# Altium schematic sheet Connectors.SchDoc(Connectors)
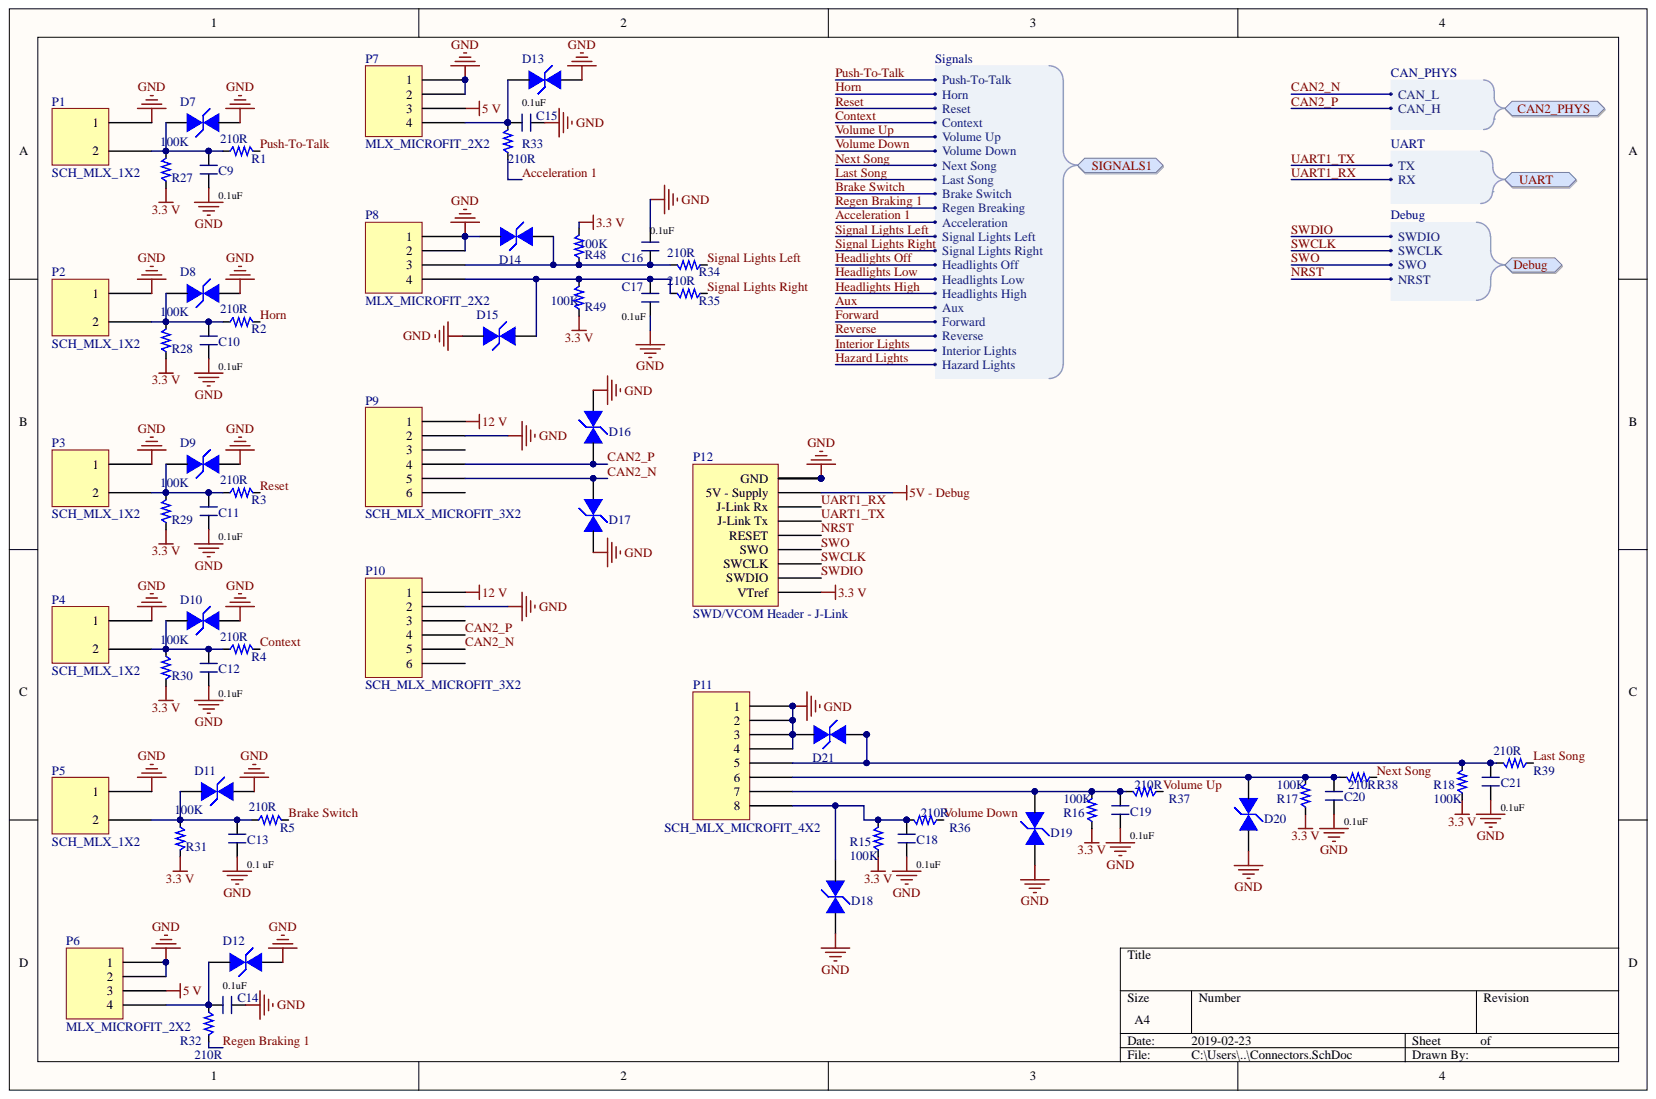
[diagram p0: Connectors.SchDoc]

## Parts
C9  Capacitance: 0.1uF  [PCB_GENERIC_SMD_0603]  (hidden comment: SCH_GENERIC_CAPACITOR_0603)
C10  Capacitance: 0.1uF  [PCB_GENERIC_SMD_0603]  (hidden comment: SCH_GENERIC_CAPACITOR_0603)
C11  Capacitance: 0.1uF  [PCB_GENERIC_SMD_0603]  (hidden comment: SCH_GENERIC_CAPACITOR_0603)
C12  Capacitance: 0.1uF  [PCB_GENERIC_SMD_0603]  (hidden comment: SCH_GENERIC_CAPACITOR_0603)
C13  Capacitance: 0.1 uF  [PCB_GENERIC_SMD_0603]  (hidden comment: SCH_GENERIC_CAPACITOR_0603)
C14  Capacitance: 0.1uF  [PCB_GENERIC_SMD_0603]  (hidden comment: SCH_GENERIC_CAPACITOR_0603)
C15  Capacitance: 0.1uF  [PCB_GENERIC_SMD_0603]  (hidden comment: SCH_GENERIC_CAPACITOR_0603)
C16  Capacitance: 0.1uF  [PCB_GENERIC_SMD_0603]  (hidden comment: SCH_GENERIC_CAPACITOR_0603)
C17  Capacitance: 0.1uF  [PCB_GENERIC_SMD_0603]  (hidden comment: SCH_GENERIC_CAPACITOR_0603)
C18  Capacitance: 0.1uF  [PCB_GENERIC_SMD_0603]  (hidden comment: SCH_GENERIC_CAPACITOR_0603)
C19  Capacitance: 0.1uF  [PCB_GENERIC_SMD_0603]  (hidden comment: SCH_GENERIC_CAPACITOR_0603)
C20  Capacitance: 0.1uF  [PCB_GENERIC_SMD_0603]  (hidden comment: SCH_GENERIC_CAPACITOR_0603)
C21  Capacitance: 0.1uF  [PCB_GENERIC_SMD_0603]  (hidden comment: SCH_GENERIC_CAPACITOR_0603)
D7  TVS Diode  [TVS Diode]  (value not drawn)
D8  TVS Diode  [TVS Diode]  (value not drawn)
D9  TVS Diode  [TVS Diode]  (value not drawn)
D10  TVS Diode  [TVS Diode]  (value not drawn)
D11  TVS Diode  [TVS Diode]  (value not drawn)
D12  TVS Diode  [TVS Diode]  (value not drawn)
D13  TVS Diode  [TVS Diode]  (value not drawn)
D14  TVS Diode  [TVS Diode]  (value not drawn)
D15  TVS Diode  [TVS Diode]  (value not drawn)
D16  TVS Diode  [TVS Diode]  (value not drawn)
D17  TVS Diode  [TVS Diode]  (value not drawn)
D18  TVS Diode  [TVS Diode]  (value not drawn)
D19  TVS Diode  [TVS Diode]  (value not drawn)
D20  TVS Diode  [TVS Diode]  (value not drawn)
D21  TVS Diode  [TVS Diode]  (value not drawn)
P1  SCH_MLX_1X2  [PCB_MLX_MICROFIT_1X2]
P2  SCH_MLX_1X2  [PCB_MLX_MICROFIT_1X2]
P3  SCH_MLX_1X2  [PCB_MLX_MICROFIT_1X2]
P4  SCH_MLX_1X2  [PCB_MLX_MICROFIT_1X2]
P5  SCH_MLX_1X2  [PCB_MLX_MICROFIT_1X2]
P6  MLX_MICROFIT_2X2  [MLX_MICROFIT_2X2]
P7  MLX_MICROFIT_2X2  [MLX_MICROFIT_2X2]
P8  MLX_MICROFIT_2X2  [MLX_MICROFIT_2X2]
P9  SCH_MLX_MICROFIT_3X2  [PCB_MLX_MICROFIT_3X2]
P10  SCH_MLX_MICROFIT_3X2  [PCB_MLX_MICROFIT_3X2]
P11  SCH_MLX_MICROFIT_4X2  [PCB_MLX_MICROFIT_4X2]
P12  SWD/VCOM Header - J-Link  [Samtec TST-110-01-G-D]
R1  Resistance: 210R  [PCB_GENERIC_SMD_0603]  (hidden comment: SCH_GENERIC_RESISTOR_0603)
R2  Resistance: 210R  [PCB_GENERIC_SMD_0603]  (hidden comment: SCH_GENERIC_RESISTOR_0603)
R3  Resistance: 210R  [PCB_GENERIC_SMD_0603]  (hidden comment: SCH_GENERIC_RESISTOR_0603)
R4  Resistance: 210R  [PCB_GENERIC_SMD_0603]  (hidden comment: SCH_GENERIC_RESISTOR_0603)
R5  Resistance: 210R  [PCB_GENERIC_SMD_0603]  (hidden comment: SCH_GENERIC_RESISTOR_0603)
R15  Resistance: 100K  [PCB_GENERIC_SMD_0603]  (hidden comment: SCH_GENERIC_RESISTOR_0603)
R16  Resistance: 100K  [PCB_GENERIC_SMD_0603]  (hidden comment: SCH_GENERIC_RESISTOR_0603)
R17  Resistance: 100K  [PCB_GENERIC_SMD_0603]  (hidden comment: SCH_GENERIC_RESISTOR_0603)
R18  Resistance: 100K  [PCB_GENERIC_SMD_0603]  (hidden comment: SCH_GENERIC_RESISTOR_0603)
R27  Resistance: 100K  [PCB_GENERIC_SMD_0603]  (hidden comment: SCH_GENERIC_RESISTOR_0603)
R28  Resistance: 100K  [PCB_GENERIC_SMD_0603]  (hidden comment: SCH_GENERIC_RESISTOR_0603)
R29  Resistance: 100K  [PCB_GENERIC_SMD_0603]  (hidden comment: SCH_GENERIC_RESISTOR_0603)
R30  Resistance: 100K  [PCB_GENERIC_SMD_0603]  (hidden comment: SCH_GENERIC_RESISTOR_0603)
R31  Resistance: 100K  [PCB_GENERIC_SMD_0603]  (hidden comment: SCH_GENERIC_RESISTOR_0603)
R32  Resistance: 210R  [PCB_GENERIC_SMD_0603]  (hidden comment: SCH_GENERIC_RESISTOR_0603)
R33  Resistance: 210R  [PCB_GENERIC_SMD_0603]  (hidden comment: SCH_GENERIC_RESISTOR_0603)
R34  Resistance: 210R  [PCB_GENERIC_SMD_0603]  (hidden comment: SCH_GENERIC_RESISTOR_0603)
R35  Resistance: 210R  [PCB_GENERIC_SMD_0603]  (hidden comment: SCH_GENERIC_RESISTOR_0603)
R36  Resistance: 210R  [PCB_GENERIC_SMD_0603]  (hidden comment: SCH_GENERIC_RESISTOR_0603)
R37  Resistance: 210R  [PCB_GENERIC_SMD_0603]  (hidden comment: SCH_GENERIC_RESISTOR_0603)
R38  SCH_GENERIC_RESISTOR_0603  [PCB_GENERIC_SMD_0603]  (value not drawn)
R39  Resistance: 210R  [PCB_GENERIC_SMD_0603]  (hidden comment: SCH_GENERIC_RESISTOR_0603)
R48  Resistance: 100K  [PCB_GENERIC_SMD_0603]  (hidden comment: SCH_GENERIC_RESISTOR_0603)
R49  Resistance: 100K  [PCB_GENERIC_SMD_0603]  (hidden comment: SCH_GENERIC_RESISTOR_0603)

## Ports
CAN2_PHYS, CAN2_PHYS.CAN_H, CAN2_PHYS.CAN_L, Debug, Debug.NRST, Debug.SWCLK, Debug.SWDIO, Debug.SWO, SIGNALS1, SIGNALS1.Acceleration, SIGNALS1.Aux, SIGNALS1.Brake Switch, SIGNALS1.Context, SIGNALS1.Forward, SIGNALS1.Hazard Lights, SIGNALS1.Headlights High, SIGNALS1.Headlights Low, SIGNALS1.Headlights Off, SIGNALS1.Horn, SIGNALS1.Interior Lights, SIGNALS1.Last Song, SIGNALS1.Next Song, SIGNALS1.Push-To-Talk, SIGNALS1.Regen Breaking, SIGNALS1.Reset, SIGNALS1.Reverse, SIGNALS1.Signal Lights Left, SIGNALS1.Signal Lights Right, SIGNALS1.Volume Down, SIGNALS1.Volume Up, UART, UART.RX, UART.TX

## Nets
3.3 V: P12.1(VTref) R15.1 R16.1 R17.1 R18.1 R27.1 R28.1 R29.1 R30.2 R31.2 R48.2 R49.1
5 V: P6.3 P7.3
5V - Debug: P12.19(Supply)
12 V: P9.1 P10.1
GND: C9.1 C10.1 C11.1 C12.2 C13.2 C14.2 C15.1 C16.1 C17.1 C18.1 C19.1 C20.1 C21.1 D7.1 D8.2 D9.2 D10.2 D11.2 D12.2 D13.2 D14.1 D15.1 D16.2 D17.1 D18.2 D19.2 D20.2 D21.1 P1.1 P2.1 P3.1 P4.1 P5.1 P6.1 P6.2 P7.1 P7.2 P8.1 P8.2 P9.2 P10.2 P11.1 P11.2 P11.3 P11.4 P12.4(GND) P12.6 P12.8 P12.10 P12.12
Acceleration 1: R33.1 ; port SIGNALS1
Brake Switch: R5.2 ; port SIGNALS1
CAN2_N: D17.2 P9.5 P10.5 ; port CAN2_PHYS
CAN2_P: D16.1 P9.4 P10.4 ; port CAN2_PHYS
Context: R4.2 ; port SIGNALS1
Horn: R2.2 ; port SIGNALS1
Last Song: R39.1 ; port SIGNALS1
Next Song: R38.1 ; port SIGNALS1
NRST: P12.15(RESET) ; port Debug
Push-To-Talk: R1.2 ; port SIGNALS1
Regen Braking 1: R32.2 ; port SIGNALS1
Reset: R3.2 ; port SIGNALS1
Signal Lights Left: R34.1 ; port SIGNALS1
Signal Lights Right: R35.1 ; port SIGNALS1
SWCLK: P12.9(SWCLK) ; port Debug
SWDIO: P12.7(SWDIO) ; port Debug
SWO: P12.13(SWO) ; port Debug
UART1_RX: P12.17(Rx) ; port UART
UART1_TX: P12.5(Tx) ; port UART
Volume Down: R36.1 ; port SIGNALS1
Volume Up: R37.1 ; port SIGNALS1
NetC9_2: C9.2 D7.2 P1.2 R1.1 R27.2
NetC10_2: C10.2 D8.1 P2.2 R2.1 R28.2
NetC11_2: C11.2 D9.1 P3.2 R3.1 R29.2
NetC12_1: C12.1 D10.1 P4.2 R4.1 R30.1
NetC13_1: C13.1 D11.1 P5.2 R5.1 R31.1
NetC14_1: C14.1 D12.1 P6.4 R32.1
NetC15_2: C15.2 D13.1 P7.4 R33.2
NetC16_2: C16.2 D14.2 P8.3 R34.2 R48.1
NetC17_2: C17.2 D15.2 P8.4 R35.2 R49.2
NetC18_2: C18.2 D18.1 P11.8 R15.2 R36.2
NetC19_2: C19.2 D19.1 P11.7 R16.2 R37.2
NetC20_2: C20.2 D20.1 P11.6 R17.2 R38.2
NetC21_2: C21.2 D21.2 P11.5 R18.2 R39.2
Aux: ; port SIGNALS1
Forward: ; port SIGNALS1
Hazard Lights: ; port SIGNALS1
Headlights High: ; port SIGNALS1
Headlights Low: ; port SIGNALS1
Headlights Off: ; port SIGNALS1
Interior Lights: ; port SIGNALS1
Reverse: ; port SIGNALS1

Not connected: P9 3 6; P10 3 6

## Library data of the parts
C9-C21 = SCH_GENERIC_CAPACITOR_0603: Generic SMD capacitor 0603; Tolerance: *%; Library Name: Generic 0603 Capacitor.SchLib; Component Kind: Standard
D7-D21 = TVS Diode: Manufacturer ID: CDSOD323-T03SC; Manufacturer: Bourns; Library Name: TVS Diode.SchLib; Component Kind: Standard
P11 = SCH_MLX_MICROFIT_4X2: Molex Microfit Connector 4x2; Library Name: Molex Microfit 3.0 4x2.SchLib; Component Kind: Standard
P12 = SWD/VCOM Header: Library Name: Samtec SWD+VCOM Header - J-Link.SchLib; Component Kind: Standard
R1-R5 R15-R18 R27-R37 R39 R48 R49 = SCH_GENERIC_RESISTOR_0603: Generic SMD resistor 0603; Library Name: Generic 0603 Resistor.SchLib; Component Kind: Standard
R38 = SCH_GENERIC_RESISTOR_0603: Generic SMD resistor 0603; Resistance: 210R; Library Name: Generic 0603 Resistor.SchLib; Component Kind: Standard
P1-P5 = SCH_MLX_1X2: Library Name: Molex Microfit 3.0 1x2.SchLib; Component Kind: Standard
P6-P8 = MLX_MICROFIT_2X2: Library Name: Molex Microfit 3.0 2x2.SchLib; Component Kind: Standard
P9 P10 = SCH_MLX_MICROFIT_3X2: Library Name: Molex Microfit 3.0 3x2.SchLib; Component Kind: Standard
